# Altium schematic sheet foxbms2-interface-mc33664.SchDoc(foxbms2-interface-mc33664)
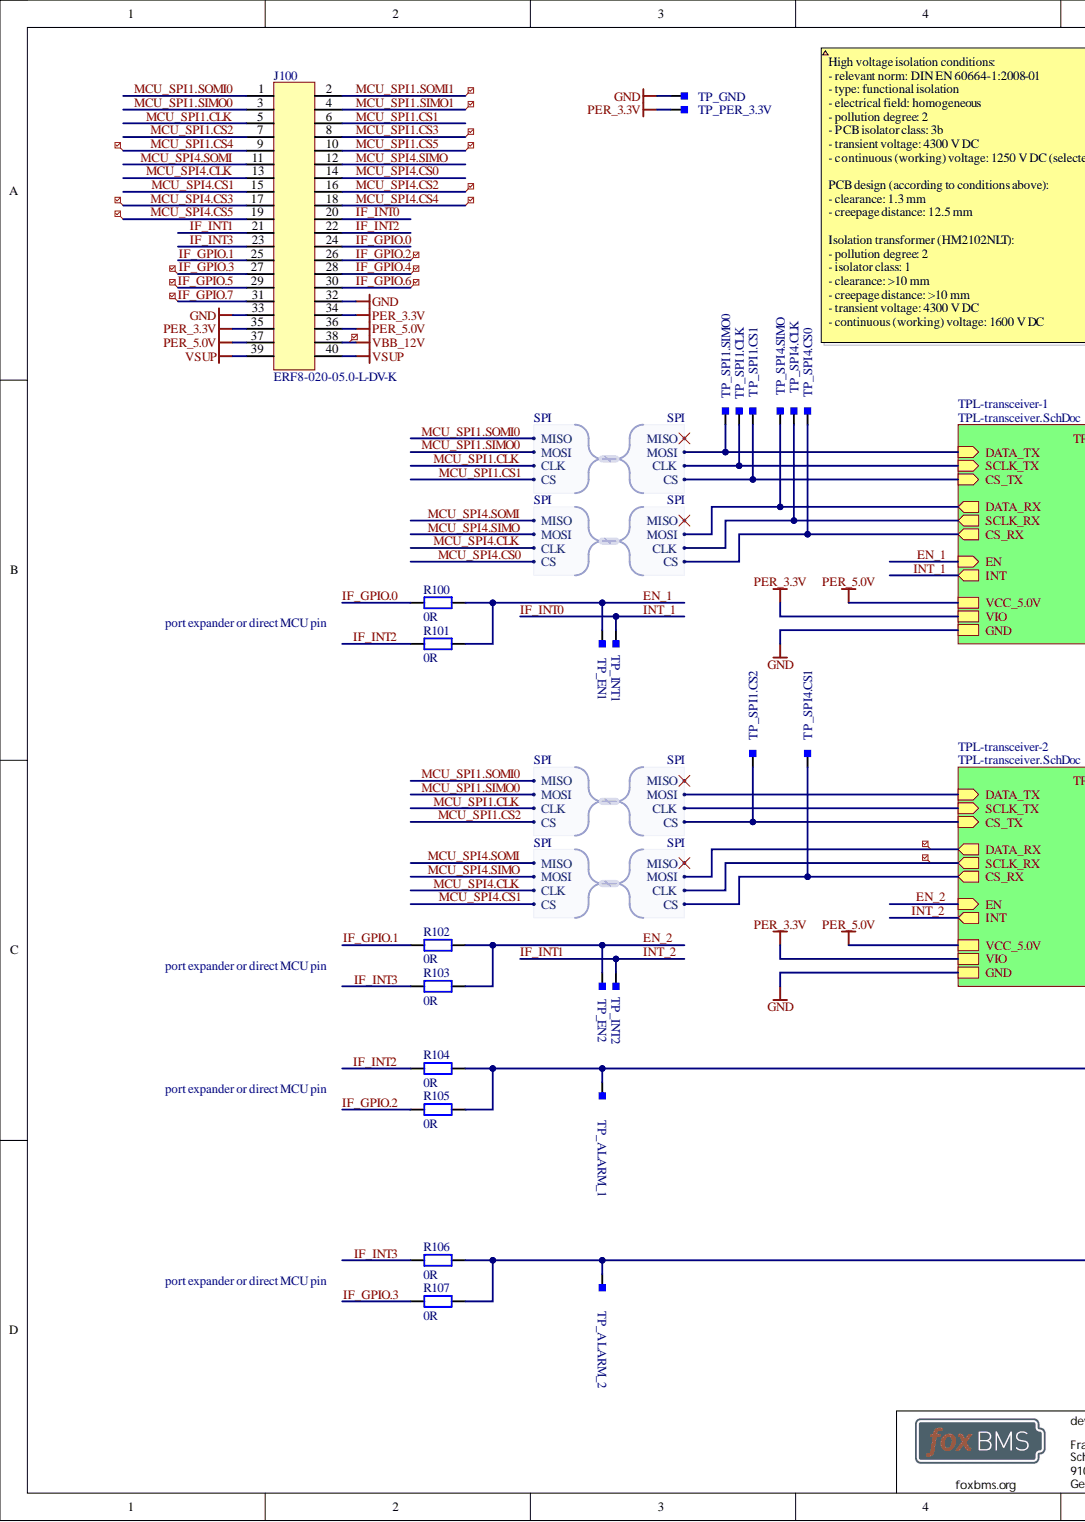
[diagram p0: foxbms2-interface-mc33664.SchDoc, left]
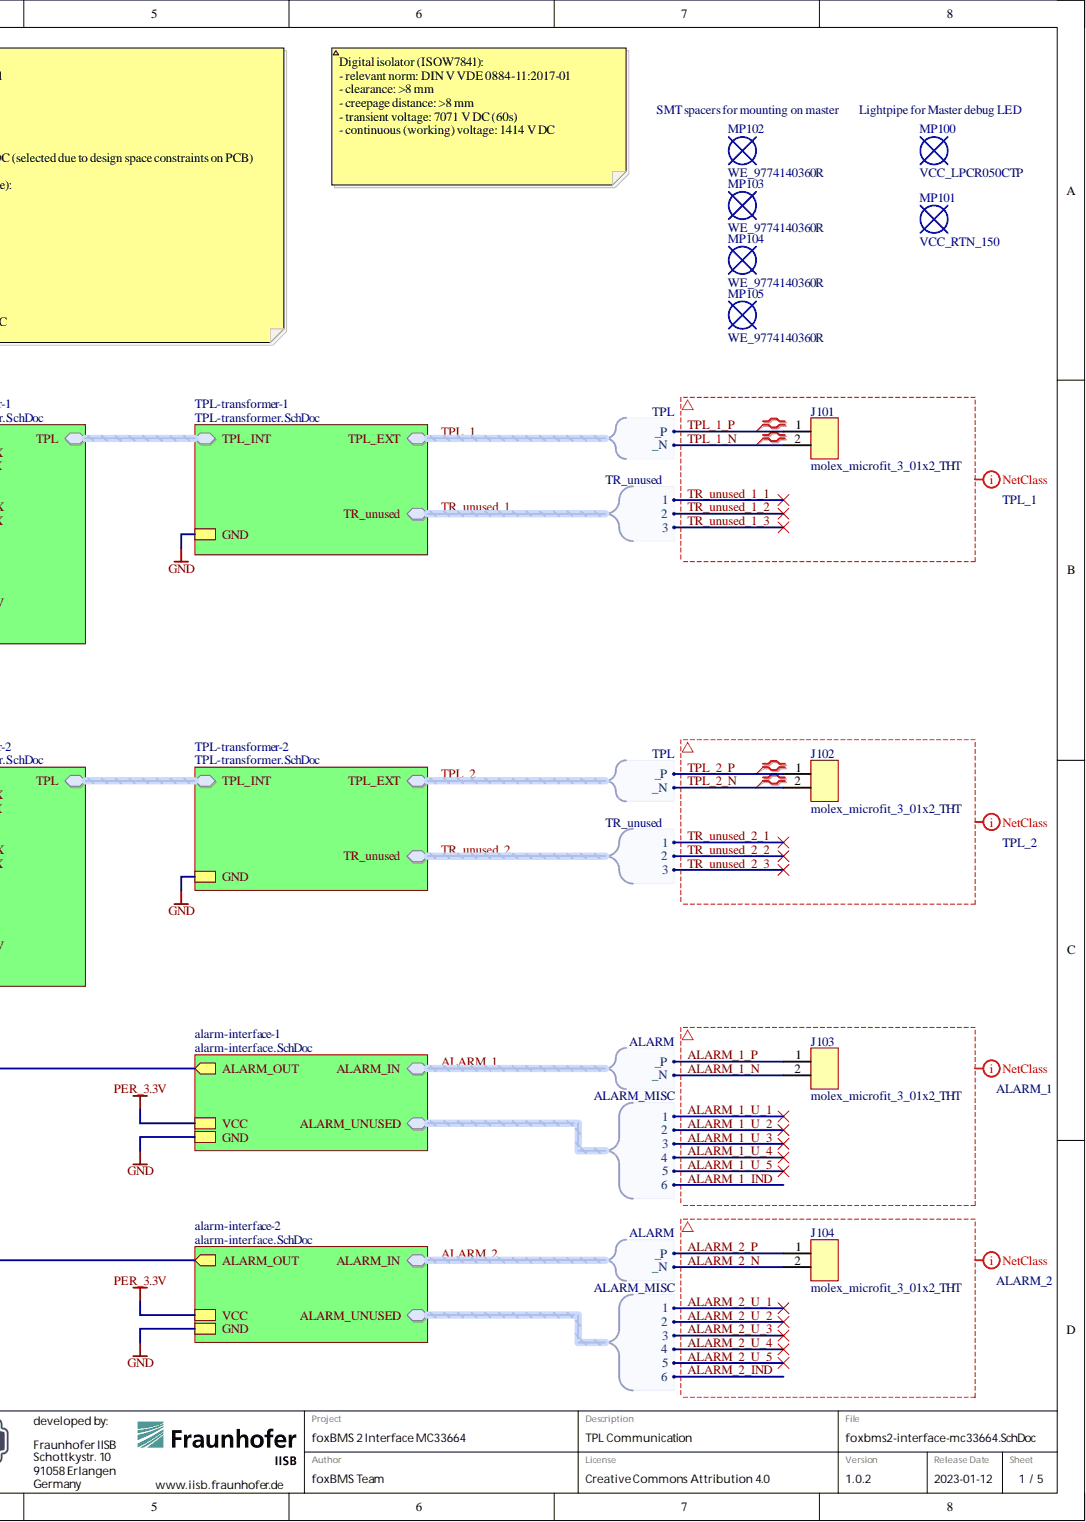
[diagram p1: foxbms2-interface-mc33664.SchDoc, right]

## Parts
J100  ERF8-020-05.0-L-DV-K  [SAMTEC_ERF8-020-05.0-L-DV-K]
J101  molex_microfit_3_01x2_THT  [MOLEX_MICROFIT_01x2_THT]
J102  molex_microfit_3_01x2_THT  [MOLEX_MICROFIT_01x2_THT]
J103  molex_microfit_3_01x2_THT  [MOLEX_MICROFIT_01x2_THT]
J104  molex_microfit_3_01x2_THT  [MOLEX_MICROFIT_01x2_THT]
MP100  VCC_LPCR050CTP  [VCC_LPCR050TP]  (mechanical)
MP101  VCC_RTN_150  [VCC_RTN_150]  (mechanical)
MP102  WE_9774140360R  [WE_9774140360]
MP103  WE_9774140360R  [WE_9774140360]
MP104  WE_9774140360R  [WE_9774140360]
MP105  WE_9774140360R  [WE_9774140360]
R100  0R  [RESC1608X05N]
R101  0R  [RESC1608X05N]
R102  0R  [RESC1608X05N]
R103  0R  [RESC1608X05N]
R104  0R  [RESC1608X05N]
R105  0R  [RESC1608X05N]
R106  0R  [RESC1608X05N]
R107  0R  [RESC1608X05N]
TP_ALARM_1  Testpoint_Square  [Testpoint_S1]  (value not drawn)  (no BOM)
TP_ALARM_2  Testpoint_Square  [Testpoint_S1]  (value not drawn)  (no BOM)
TP_EN1  Testpoint_Square  [Testpoint_S1]  (value not drawn)  (no BOM)
TP_EN2  Testpoint_Square  [Testpoint_S1]  (value not drawn)  (no BOM)
TP_GND  Testpoint_Square  [Testpoint_S1]  (value not drawn)  (no BOM)
TP_INT1  Testpoint_Square  [Testpoint_S1]  (value not drawn)  (no BOM)
TP_INT2  Testpoint_Square  [Testpoint_S1]  (value not drawn)  (no BOM)
TP_PER_3.3V  Testpoint_Square  [Testpoint_S1]  (value not drawn)  (no BOM)
TP_SPI1.CLK  Testpoint_Square  [Testpoint_S1]  (value not drawn)  (no BOM)
TP_SPI1.CS1  Testpoint_Square  [Testpoint_S1]  (value not drawn)  (no BOM)
TP_SPI1.CS2  Testpoint_Square  [Testpoint_S1]  (value not drawn)  (no BOM)
TP_SPI1.SIMO0  Testpoint_Square  [Testpoint_S1]  (value not drawn)  (no BOM)
TP_SPI4.CLK  Testpoint_Square  [Testpoint_S1]  (value not drawn)  (no BOM)
TP_SPI4.CS0  Testpoint_Square  [Testpoint_S1]  (value not drawn)  (no BOM)
TP_SPI4.CS1  Testpoint_Square  [Testpoint_S1]  (value not drawn)  (no BOM)
TP_SPI4.SIMO  Testpoint_Square  [Testpoint_S1]  (value not drawn)  (no BOM)

## Nets
GND: J100.32 J100.33 TP_GND.1
PER_3.3V: J100.34 J100.35 TP_PER_3.3V.1
PER_5.0V: J100.36 J100.37
VBB_12V: J100.38
VSUP: J100.39 J100.40
~{IF_INT0}: J100.20 TP_INT1.1
~{IF_INT1}: J100.21 TP_INT2.1
~{IF_INT2}: J100.22 R101.1 R104.1
~{IF_INT3}: J100.23 R103.1 R106.1
~{MCU_SPI1.CS1}: J100.6 TP_SPI1.CS1.1
~{MCU_SPI1.CS2}: J100.7 TP_SPI1.CS2.1
~{MCU_SPI1.CS3}: J100.8
~{MCU_SPI1.CS4}: J100.9
~{MCU_SPI1.CS5}: J100.10
~{MCU_SPI4.CS0}: J100.14 TP_SPI4.CS0.1
~{MCU_SPI4.CS1}: J100.15 TP_SPI4.CS1.1
~{MCU_SPI4.CS2}: J100.16
~{MCU_SPI4.CS3}: J100.17
~{MCU_SPI4.CS4}: J100.18
~{MCU_SPI4.CS5}: J100.19
ALARM_1._N: J103.2  [also labelled ALARM_1_IND, ALARM_1_U_1, ALARM_1_U_2, ALARM_1_U_3, ALARM_1_U_4, ALARM_1_U_5]
ALARM_1._P: J103.1
ALARM_2._N: J104.2  [also labelled ALARM_2_IND, ALARM_2_U_1, ALARM_2_U_2, ALARM_2_U_3, ALARM_2_U_4, ALARM_2_U_5]
ALARM_2._P: J104.1
EN_1: R100.2 R101.2 TP_EN1.1
EN_2: R102.2 R103.2 TP_EN2.1
IF_GPIO.0: J100.24 R100.1
IF_GPIO.1: J100.25 R102.1
IF_GPIO.2: J100.26 R105.1
IF_GPIO.3: J100.27 R107.1
IF_GPIO.4: J100.28
IF_GPIO.5: J100.29
IF_GPIO.6: J100.30
IF_GPIO.7: J100.31
MCU_SPI1.CLK: J100.5 TP_SPI1.CLK.1
MCU_SPI1.SIMO0: J100.3 TP_SPI1.SIMO0.1
MCU_SPI1.SIMO1: J100.4
MCU_SPI1.SOMI0: J100.1
MCU_SPI1.SOMI1: J100.2
MCU_SPI4.CLK: J100.13 TP_SPI4.CLK.1
MCU_SPI4.SIMO: J100.12 TP_SPI4.SIMO.1
MCU_SPI4.SOMI: J100.11
TPL_1._N: J101.2
TPL_1._P: J101.1
TPL_2._N: J102.2
TPL_2._P: J102.1
NetR104_2: R104.2 R105.2 TP_ALARM_1.1
NetR106_2: R106.2 R107.2 TP_ALARM_2.1
TPL._N:
TPL._P:
TR_unused_1.1:
TR_unused_1.2:
TR_unused_1.3:
TR_unused_2.1:
TR_unused_2.2:
TR_unused_2.3:

## Library data of the parts
J100 = SAMTEC_ERF8-020-05.0-L-DV-K: Edge Rate rugged high-speed connector strips; Manufacturer: Samtec; Automotive Qualified: False; Circuits: 40; Component Kind: Standard; ComponentLink1Description: Datasheet; ComponentLink1URL: https://suddendocs.samtec.com/catalog_english/erf8-s.pdf; Database Table Name: Connector; ECCN: EAR99; Library Name: OE750.DbLib; Operating Temperature: -55°C - +125°C; Part Name: ERF8-020-05.0-L-DV-K; Part Number: SAMTEC_ERF8-020-05.0-L-DV-K; Supplier 1: Farnell; Supplier 2: Digikey; Supplier 3: Mouser; Supplier Part Number 1: 3771957; Supplier Part Number 2: SAM13550CT-ND; Supplier Part Number 3: 200-ERF8020050LDVKTR; System: Connector
J101-J104 = molex_microfit_3_01x2_THT: Micro-Fit 3.0 Right Angle Header, 3.00mm Pitch, Dual Row, 2 Circuits, with Snap-in Plastic Peg PCB Lock, Tin, Glow Wire Capable, Black, 43045-0200; Manufacturer: Molex; Automotive Qualified: False; Circuits: 2; Database Table Name: Connector; Rated Current: 8.5A; Rated Voltage: 600V; Component Kind: Standard; Library Name: OE750.DbLib; Operating Temperature: -40°C - +105°C; Part Name: MicroFit; Part Number: molex_microfit_3_01x2_THT; Supplier 1: Farnell; Supplier Part Number 1: 1012251
MP100 = VCC_LPCR050CTP: Lightpipe Clear LPCR Series; Manufacturer: VCC; Automotive Qualified: False; Database Table Name: Misc; ComponentLink1Description: Datasheet; ComponentLink1URL: https://vcclite.com/wp-content/uploads/wpallimport/files/files/LPCR.pdf; ECCN: EAR99; Library Name: OE750.DbLib; Part Name: VCC_LPCR050CTP; Part Number: VCC_LPCR050CTP; Supplier 1: Digikey; Supplier 2: Mouser; Supplier Part Number 1: LPCR050CTP-ND; Supplier Part Number 2: 593-LPCR050CTP
MP101 = VCC_RTN_150: Grommet Retainer for LPC and LPCR Series Light Pipe; Manufacturer: VCC; Automotive Qualified: False; Database Table Name: Misc; ComponentLink1Description: Datasheet; ComponentLink1URL: https://vcclite.com/?file=files/RTN_150.pdf&file_name=RTN_150.pdf; ECCN: EAR99; Library Name: OE750.DbLib; Part Name: VCC_RTN_150; Part Number: VCC_RTN_150; Supplier 1: Digikey; Supplier 2: Mouser; Supplier Part Number 1: RTN_150-ND; Supplier Part Number 2: 593-RTN150
MP102-MP105 = WE_9774140360R: WA-SMSI SMT Steel Spacer with internal thread M 3; Manufacturer: Würth Elektronik; Automotive Qualified: False; Database Table Name: Misc; Component Kind: Standard; ComponentLink1Description: Datasheet; ComponentLink1URL: https://www.we-online.com/catalog/datasheet/9774140360R.pdf; ECCN: EAR99; Library Name: OE750.DbLib; Operating Temperature: -55°C - +150°C; Part Name: WE_9774140360R; Part Number: WE_9774140360R; Supplier 1: Digikey; Supplier 2: Mouser; Supplier Part Number 1: 732-5281-1-ND; Supplier Part Number 2: 710-9774140360R
R100-R107 = gen_R_0R_0603: SMD Resistor 0R 0603; Automotive Qualified: True; Case EIA: 0603; Case Metric: 1608; Database Table Name: R; Maximum Working Voltage: 75V; PnP equipped: x; PnP Package: 0603R; Power: 0.1W; Component Kind: Standard; Library Name: OE750.DbLib; Operating Temperature: -55°C - +155°C; Part Number: gen_R_0R_0603; PnP Value: 0R; Resistance: 0R; Series: Jumper; Supplier 1: Farnell; Supplier Part Number 1: 2616580
TP_ALARM_1 TP_ALARM_2 TP_EN1 TP_EN2 TP_GND TP_INT1 TP_INT2 TP_PER_3.3V TP_SPI1.CLK TP_SPI1.CS1 TP_SPI1.CS2 TP_SPI1.SIMO0 TP_SPI4.CLK TP_SPI4.CS0 TP_SPI4.CS1 TP_SPI4.SIMO = Testpoint_Square: Testpoint, square; Automotive Qualified: False; Database Table Name: Misc (No BOM); Library Name: OE750.DbLib; Part Number: Testpoint_Square
